# Altium schematic sheet Sheet3.SchDoc
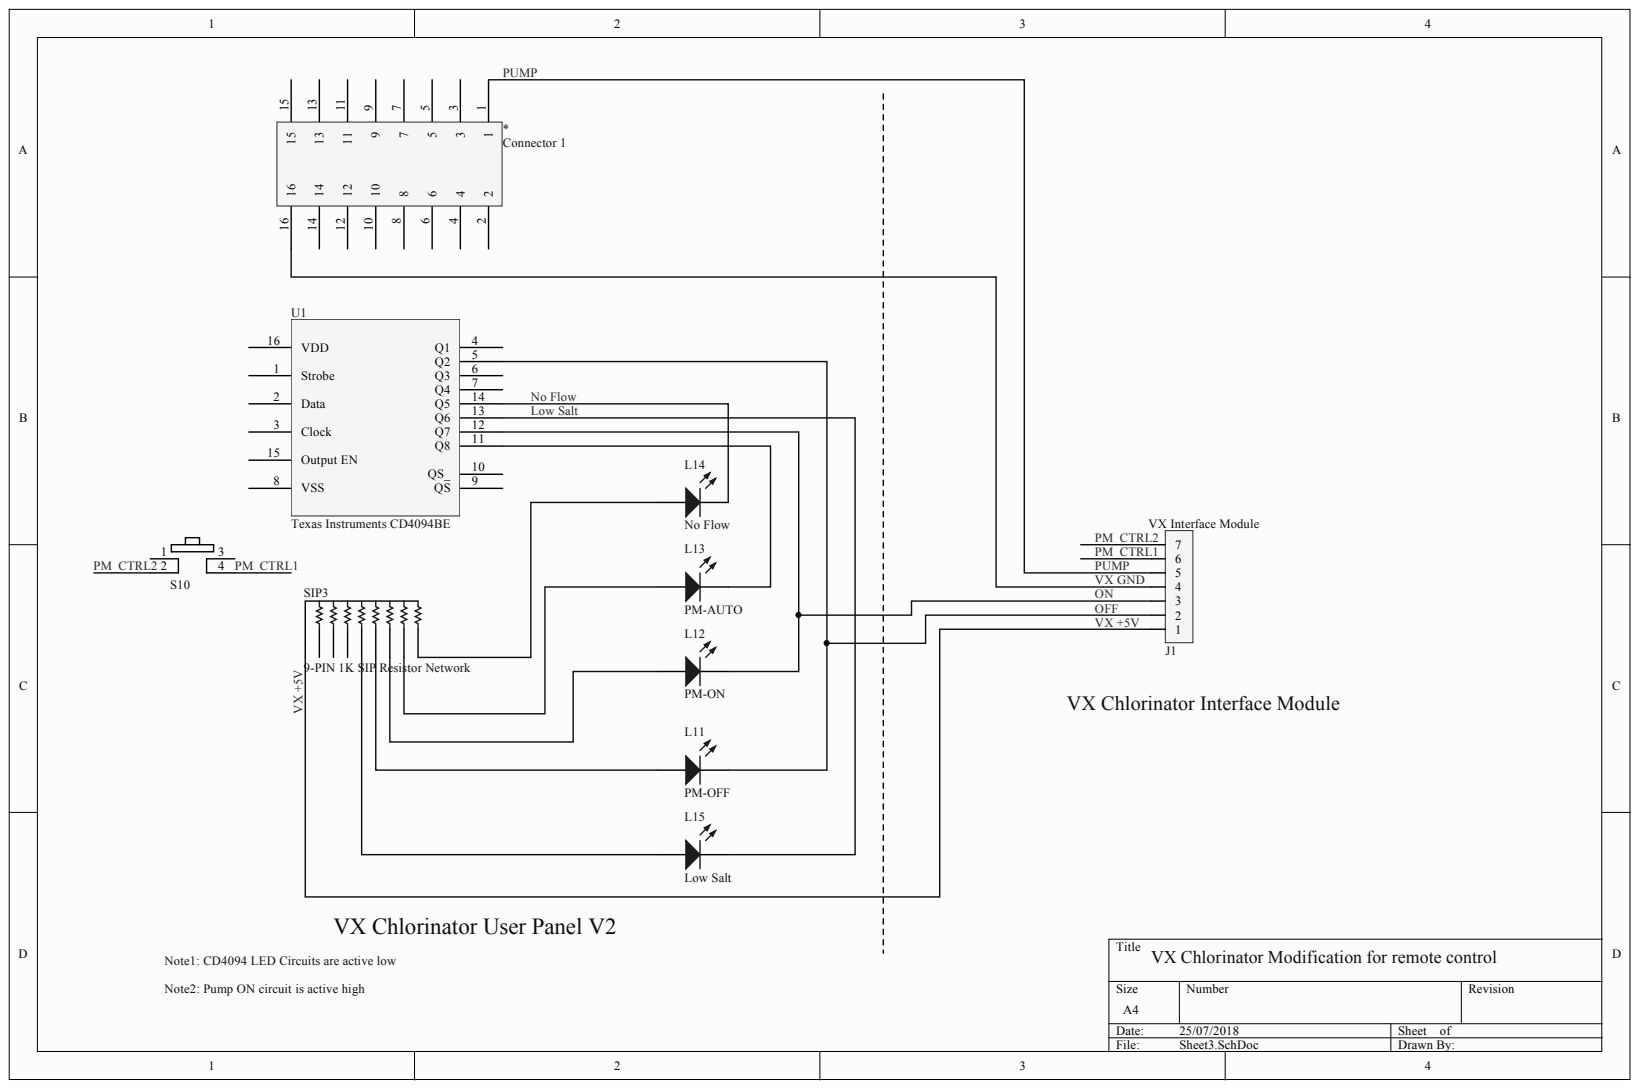
[diagram p0: Sheet3.SchDoc]

## Parts
*  Connector 1  [PCB-4ado64b322jaydefijtm-1]
J1  VX Interface Module  [PCBComponent_1 - duplicate]
L11  PM-OFF  [PCB-t8a8bkv5t5yzrq5f3vco-1]
L12  PM-ON  [PCB-t8a8bkv5t5yzrq5f3vco-1]
L13  PM-AUTO  [PCB-t8a8bkv5t5yzrq5f3vco-1]
L14  No Flow  [PCB-t8a8bkv5t5yzrq5f3vco-1]
L15  Low Salt  [PCB-t8a8bkv5t5yzrq5f3vco-1]
S10  SYM-62e9a967b90dbd2e-1  [PCB-gaic1pl4705ifv3nefaq-1]  (value not drawn)
SIP3  9-PIN 1K SIP Resistor Network  [PCB-utt95prcxgjdn02n3us6-1]
U1  Texas Instruments CD4094BE  [PCB-srtooyg9erax554gp5vn-1]

## Nets
LOW SALT: L15.2 U1.13(Q6)
NO FLOW: L14.2 U1.14(Q5)
OFF: J1.2 L11.2 U1.5(Q2)
ON: J1.3 L12.2 U1.12(Q7)
PM_CTRL1: J1.6 S10.4
PM_CTRL2: J1.7 S10.2
PUMP: *.1 J1.5
VX +5V: J1.1 SIP3.1
VX GND: *.16 J1.4
Net*_2: *.2
NetL11_1: L11.1 SIP3.6
NetL12_1: L12.1 SIP3.7
NetL13_1: L13.1 SIP3.8
NetL13_2: L13.2 U1.11(Q8)
NetL14_1: L14.1 SIP3.9
NetL15_1: L15.1 SIP3.5

Not connected: * 3 4 5 6 7 8 9 10 11 12 13 14 15; S10 1 3; SIP3 2 3 4; U1 1(Strobe) 2(Data) 3(Clock) 4(Q1) 6(Q3) 7(Q4) 8(VSS) 9(QS) 10(QS_) 15(Output_EN) 16(VDD)

## Library data of the parts
* = CMP-130ada1aefe5cccd-4: Wire-To-Board Connector, Straight, SEK 18 Series, Through Hole, Header, 16, 2.54 mm; Number of Contacts: 16; Number of Mating Cycles: 200; Color: Gray; Component Kind: Standard; Contact Plating: Gold; Flammability Rating: UL 94 V-0; Library Name: CommunityVault
J1 = CMP-b84c7047322f678b-1: Conn Unshrouded Header HDR 7 POS 2.54mm Solder ST Thru-Hole Bag; Component Kind: Standard; Library Name: CommunityVault
L11-L15 = CMP-29a0e0a26f5af667-2: Green 5 mm T-1 3/4 36° Tinted Diffused 19 mcd 2.1 LED Through Hole; Component Kind: Standard; Lens Type: Diffused; Library Name: CommunityVault; Mounting Style: Through Hole; Size-Height: 8.60 mm
S10 = CMP-3ee8f78971b1e12f-13: Switch Tactile OFF (ON) SPST Round Button PC Pins 0.05A 24VDC 100000Cycles 1.57N Thru-Hole Loose; ELV Compliant: Compliant; Component Kind: Standard; Digikey: 450-1650-ND; Library Name: CommunityVault; OctopartID: 62e9a967b90dbd2e; Octopart URL: https://octopart.com/1825910-6-te+connectivity-42270338; Price: $0.10
SIP3 = CMP-b5267c226cb76ae1-1: Res Thick Film NET 1K Ohm 2% 1.13W ±100ppm/°C BUS Molded 9-Pin SIP Pin Thru-Hole; Component Kind: Standard; Library Name: CommunityVault; Number of Pins: 9; REACH SVHC Compliance: No SVHC; Resistance Tolerance: ±2%
U1 = CMP-026fc93edcf6dda8-6: 4000 Series 3 to 20 V Eight Stage Through Hole Shift / Store Bus Register-DIP-16; Component Kind: Standard; Library Name: CommunityVault
